# Altium schematic sheet Power.SchDoc(Power)
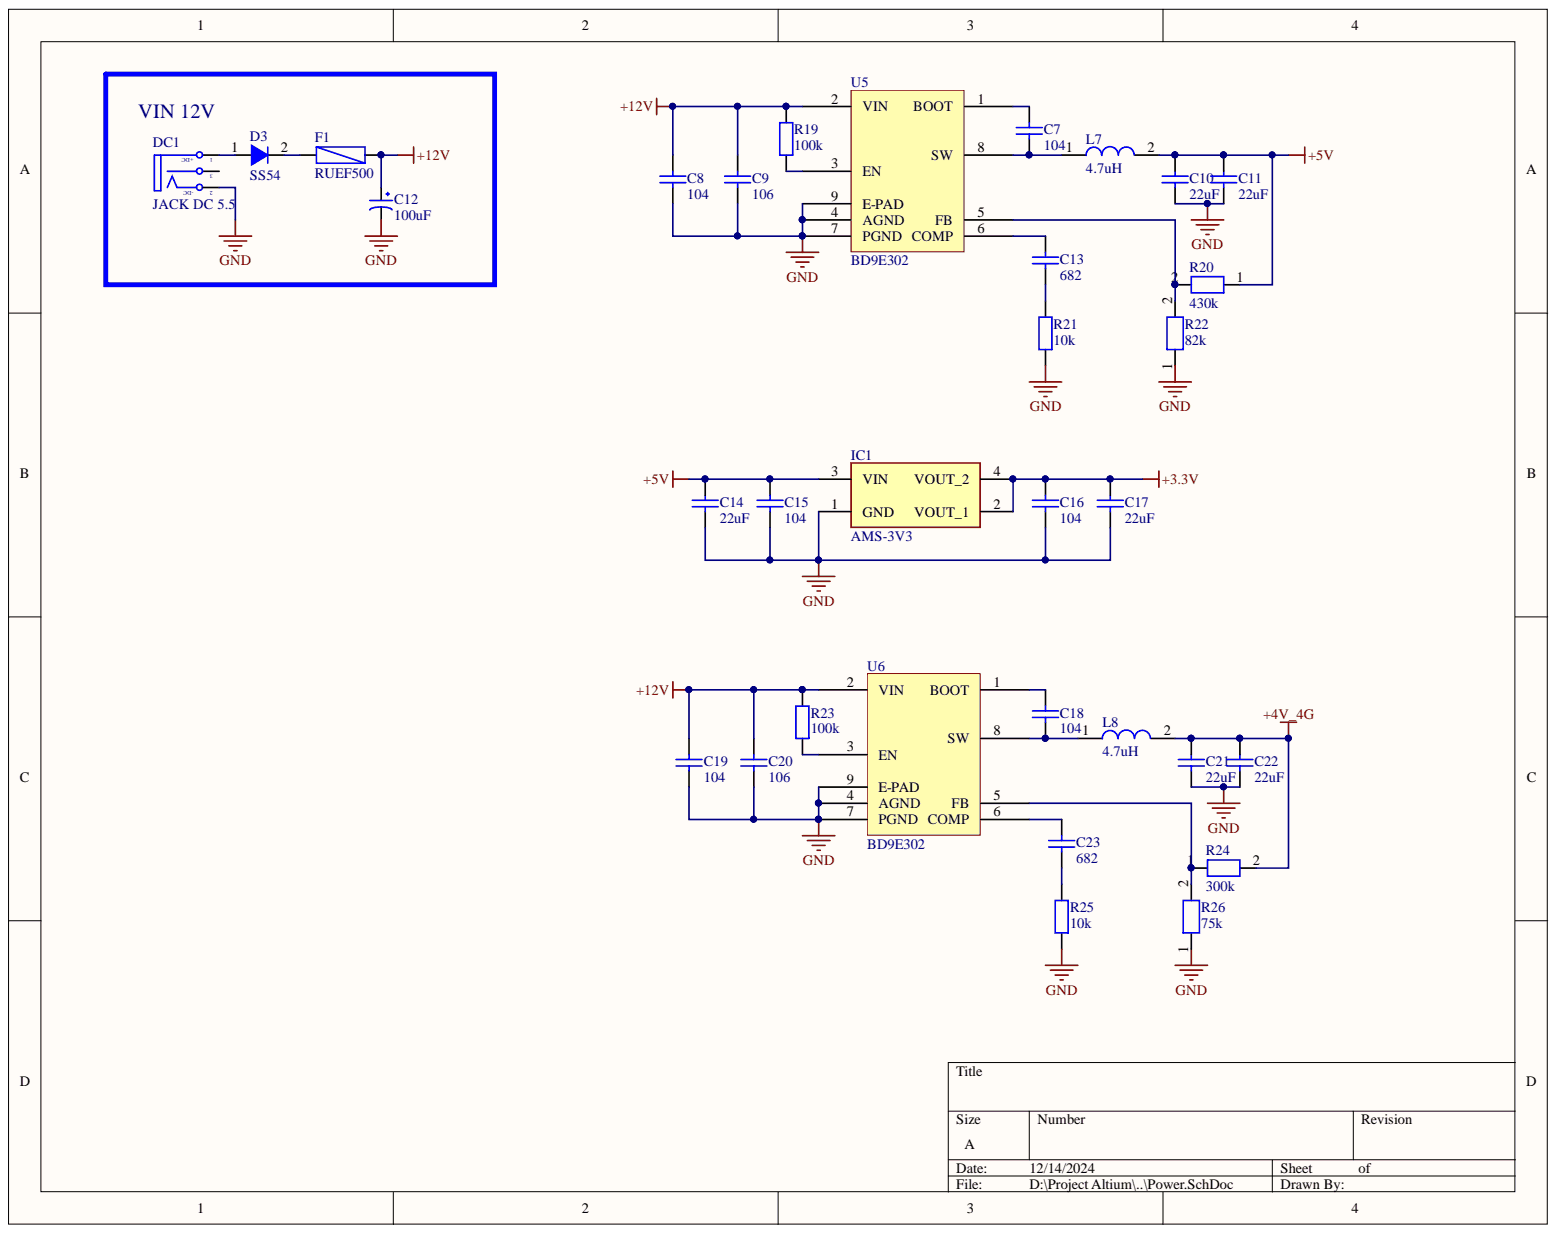
[diagram p0: Power.SchDoc]

## Parts
C7  104  [tu 0603]
C8  104  [tu 0603]
C9  106  [tu 0805]
C10  22uF  [Tu 0805]
C11  22uF  [Tu 0805]
C12  100uF  [tu hoa 5mm]
C13  682  [Tu 0603]
C14  22uF  [Tu 0805]
C15  104  [tu 0603]
C16  104  [tu 0603]
C17  22uF  [Tu 0805]
C18  104  [tu 0603]
C19  104  [tu 0603]
C20  106  [tu 0805]
C21  22uF  [Tu 0805]
C22  22uF  [Tu 0805]
C23  682  [Tu 0603]
D3  SS54  [SS54]
DC1  JACK DC 5.5  [JACK DC 5.5]
F1  RUEF500  [RUEF500 - duplicate]
IC1  AMS-3V3  [SOT229P700X180-4N]
L7  4.7uH  [CDRH6D38-4R7]
L8  4.7uH  [CDRH6D38-4R7]
R19  100k  [tro smd 0603]
R20  430k  [TRO SMD 0603]
R21  10k  [tro smd 0603]
R22  82k  [TRO SMD 0603]
R23  100k  [tro smd 0603]
R24  300k  [TRO SMD 0603]
R25  10k  [tro smd 0603]
R26  75k  [TRO SMD 0603]
U5  BD9E302  [BD9E302]
U6  BD9E302  [BD9E302]

## Nets
+3.3V: C16.1 C17.2 IC1.2(VOUT_1) IC1.4(VOUT_2)
+4V_4G: C21.2 C22.2 L8.2 R24.2
+5V: C10.2 C11.2 C14.2 C15.1 IC1.3(VIN) L7.2 R20.1
+12V: C8.1 C9.1 C12.1 C19.1 C20.1 F1.2 R19.2 R23.2 U5.2(VIN) U6.2(VIN)
GND: C8.2 C9.2 C10.1 C11.1 C12.2 C14.1 C15.2 C16.2 C17.1 C19.2 C20.2 C21.1 C22.1 DC1.2 IC1.1(GND) R21.1 R22.1 R25.1 R26.1 U5.4(AGND) U5.7(PGND) U5.9(E-PAD) U6.4(AGND) U6.7(PGND) U6.9(E-PAD)
NetC7_1: C7.1 U5.1(BOOT)
NetC7_2: C7.2 L7.1 U5.8(SW)
NetC13_1: C13.1 U5.6(COMP)
NetC13_2: C13.2 R21.2
NetC18_1: C18.1 U6.1(BOOT)
NetC18_2: C18.2 L8.1 U6.8(SW)
NetC23_1: C23.1 U6.6(COMP)
NetC23_2: C23.2 R25.2
NetD3_1: D3.1 DC1.1
NetD3_2: D3.2 F1.1
NetR19_1: R19.1 U5.3(EN)
NetR20_2: R20.2 R22.2 U5.5(FB)
NetR23_1: R23.1 U6.3(EN)
NetR24_1: R24.1 R26.2 U6.5(FB)

Not connected: DC1 3

## Library data of the parts
C7 C8 C15 C16 C18 C19 = Tu 0603 104 50V 10%: Dán; Ten_Linh_Kien: Tụ 0603 104-100nF 10% 50V; So_Chan: 2; Ma_Hang: MH004568; Don_Vi: Con; Library Name: Tu dien.SchLib; Component Kind: Standard; Chung_Loai: Dán
C9 C20 = Tu 0805 106 16V 10%: Dán; Ten_Linh_Kien: Tụ 0805 106-10uF 10% 16V; So_Chan: 2; Ma_Hang: MH004553; Don_Vi: Con; Library Name: Tu dien.SchLib; Component Kind: Standard; Chung_Loai: Dán
C10 C11 C14 C17 C21 C22 = 0805-22uF: 0805 22uF; Library Name: test.SchLib; Component Kind: Standard
C12 = Tu Hoa 100uF 25V 6x11: Cắm; Ten_Linh_Kien: Tụ Hóa 100uF 25V 6x11MM; So_Chan: 2; Ma_Hang: MH001351; Don_Vi: Con; Library Name: Tu dien.SchLib; Component Kind: Standard; Chung_Loai: Cắm
C13 C23 = 0603_682_6800pF_6.8nF: cap 0603_682_6800pF_6.8nF; Manufacturer_Part_Number: ams1117-3.3v; Manufacturer_Name: Advanced Monolithic Systems; Library Name: test.SchLib; Height: 1.8mm; Datasheet Link: http://www.advanced-monolithic.com/pdf/ds1117.pdf; Component Kind: Standard
D3 = SS54: DIode Schottky SS54; Library Name: test.SchLib; Component Kind: Standard
DC1 = JACK DC 5.5: Value: Jac DC; Library Name: Component.SchLib; Description: JACK DC 5.5; Component Kind: Standard
F1 = RUEF500: Resettable Fuse 5A 30V; Library Name: test.SchLib; Component Kind: Standard
IC1 = ams1117-3.3v: IC power AMS1117 3V3; Manufacturer_Name: Advanced Monolithic Systems; Manufacturer_Part_Number: ams1117-3.3v; Library Name: test.SchLib; Height: 1.8mm; Datasheet Link: http://www.advanced-monolithic.com/pdf/ds1117.pdf; Component Kind: Standard
L7 L8 = CDRH6D38NP-4R7-4.7uH: Inductor CDRH6D38NP-4R7-4.7uH; Library Name: test.SchLib; Component Kind: Standard
R19 R23 = Tro 0603 5% 100k: Dán; Ten_Linh_Kien: Trở 0603 5% 100k; So_Chan: 2; Ma_Hang: MH006314; Don_Vi: Con; Library Name: Dien Tro.SchLib; Component Kind: Standard; Chung_Loai: Dán
R20 = R0603_430k_1%: Resistor; Manufacturer_Part_Number: CR0603-FX-1202ELF; Manufacturer_Name: Bourns; Mouser Price/Stock: https://www.mouser.com/Search/Refine.aspx?Keyword=652-CR0603FX-1202ELF; Mouser Part Number: 652-CR0603FX-1202ELF; Library Name: test.SchLib; Height: 0.55mm; Datasheet Link: https://www.bourns.com/pdfs/chpreztr.pdf; Component Kind: Standard; Arrow Price/Stock: https://www.arrow.com/en/products/cr0603-fx-1202elf/bourns; Arrow Part Number: CR0603-FX-1202ELF
R21 R25 = Tro 0603 5% 10k: Dán; Ten_Linh_Kien: Trở 0603 5% 10k; So_Chan: 2; Ma_Hang: MH006316; Don_Vi: Con; Library Name: Dien Tro.SchLib; Component Kind: Standard; Chung_Loai: Dán
R22 = R0603_82k_1%: Resistor; Manufacturer_Part_Number: CR0603-FX-1202ELF; Manufacturer_Name: Bourns; Mouser Price/Stock: https://www.mouser.com/Search/Refine.aspx?Keyword=652-CR0603FX-1202ELF; Mouser Part Number: 652-CR0603FX-1202ELF; Library Name: test.SchLib; Height: 0.55mm; Datasheet Link: https://www.bourns.com/pdfs/chpreztr.pdf; Component Kind: Standard; Arrow Price/Stock: https://www.arrow.com/en/products/cr0603-fx-1202elf/bourns; Arrow Part Number: CR0603-FX-1202ELF
R24 R26 = R_0603: R 0603; Library Name: test.SchLib; Component Kind: Standard
U5 U6 = BD9E302: IC power buck DC/DC; Library Name: test.SchLib; Component Kind: Standard
